# Altium schematic sheet Sincronismo.SchDoc
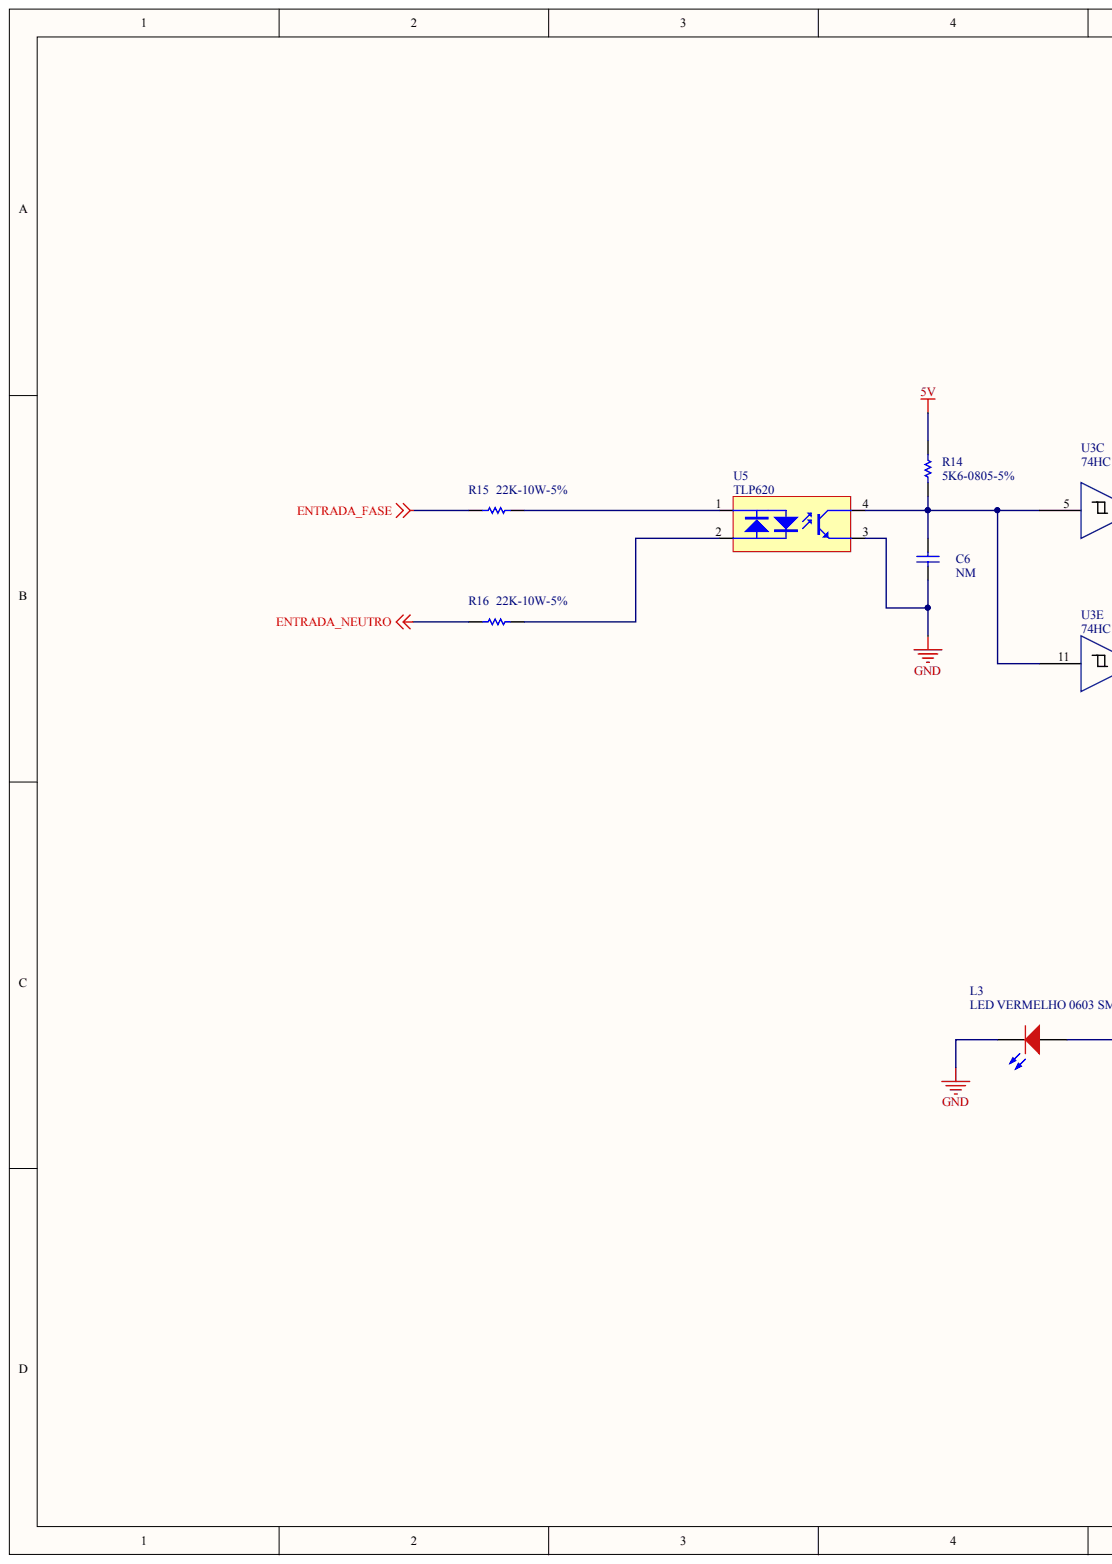
[diagram p0: Sincronismo.SchDoc, left]
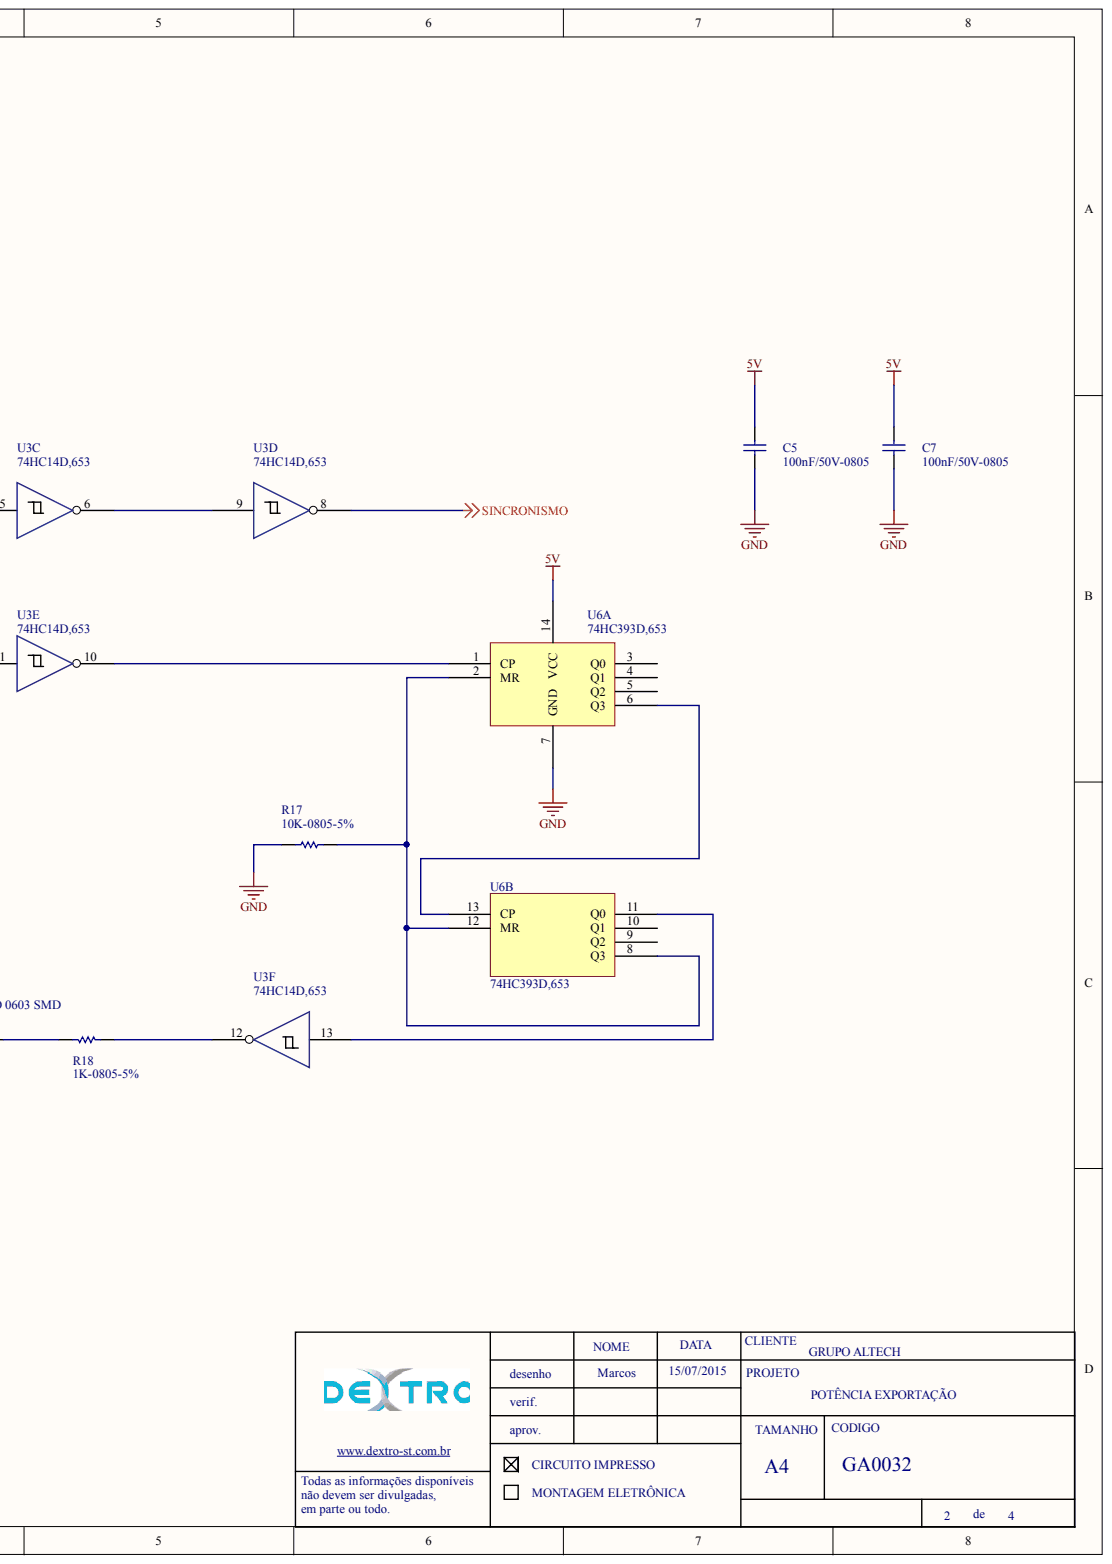
[diagram p1: Sincronismo.SchDoc, right]

## Parts
C5  100nF/50V-0805  [0805-REVISADO ONDA]
C6  NM  [0805-REVISADO ONDA]
C7  100nF/50V-0805  [0805-REVISADO ONDA]
L3  LED VERMELHO 0603 SMD  [LED 1206]
R14  5K6-0805-5%  [0805-REVISADO ONDA]
R15  22K-10W-5%  [RESISTOR 10W CERAMICO]
R16  22K-10W-5%  [RESISTOR 10W CERAMICO]
R17  10K-0805-5%  [0805-REVISADO ONDA]
R18  1K-0805-5%  [0805-REVISADO ONDA]
U3  74HC14D,653  [SOIC 14 PINOS]  (parts C,D,E,F)
U5  TLP620  [DIP-4]
U6  74HC393D,653  [SOIC 14 PINOS]  (parts A,B)

## Nets
5V: C5.2 C7.2 R14.2 U6.14(VCC)
ENTRADA_FASE: R15.1
ENTRADA_NEUTRO: R16.1
GND: C5.1 C6.1 C7.1 L3.2 R17.1 U5.3 U6.7(GND)
SINCRONISMO: U3.8
NetC6_2: C6.2 R14.1 U3.5 U3.11 U5.4
NetL3_1: L3.1 R18.2
NetR15_2: R15.2 U5.1
NetR16_2: R16.2 U5.2
NetR17_2: R17.2 U6.2(MR) U6.8(Q3) U6.12(MR)
NetR18_1: R18.1 U3.12
NetU3_6: U3.6 U3.9
NetU3_10: U3.10 U6.1(CP)
NetU3_13: U3.13 U6.11(Q0)
NetU6_6: U6.6(Q3) U6.13(CP)

Not connected: U6 3(Q0) 4(Q1) 5(Q2) 9(Q2) 10(Q1)

## Library data of the parts
C5-C7 = 100nF/50-0805-NPO: Component Kind: Standard; ERP: 104.11.005; Library Name: AquinoLib.SchLib
  C5 C7: Description: 100nF/50V-0805
  C6: Description: 100nF/50-0805-NPO
R14-R16 = 22K-0805-5%: Component Kind: Standard; Description: 22K-0805-5%; ERP: 102.11.058; Library Name: AquinoLib.SchLib
U3 = 74HC14D: Component Kind: Standard; Description: 74HC14D; Library Name: AquinoLib.SchLib
U5 = PC814: Component Kind: Standard; Description: PC814; Library Name: AquinoLib.SchLib
U6 = 74HC393: Component Kind: Standard; Library Name: AquinoLib.SchLib
L3 = LED VERMELHO 0603 SMD: Component Kind: Standard; Description: LED VERMELHO 0603 SMD; ERP: 124.02.010; Library Name: AquinoLib.SchLib
R17 = 10K-0805-5%: Component Kind: Standard; Description: 10K-0805-5%; ERP: 102.11.054; Library Name: AquinoLib.SchLib
R18 = 1K-0805-5%: Component Kind: Standard; Description: 1K-0805-5%; ERP: 102.11.042; Library Name: AquinoLib.SchLib
